# Altium schematic sheet Voltage Sense.SchDoc
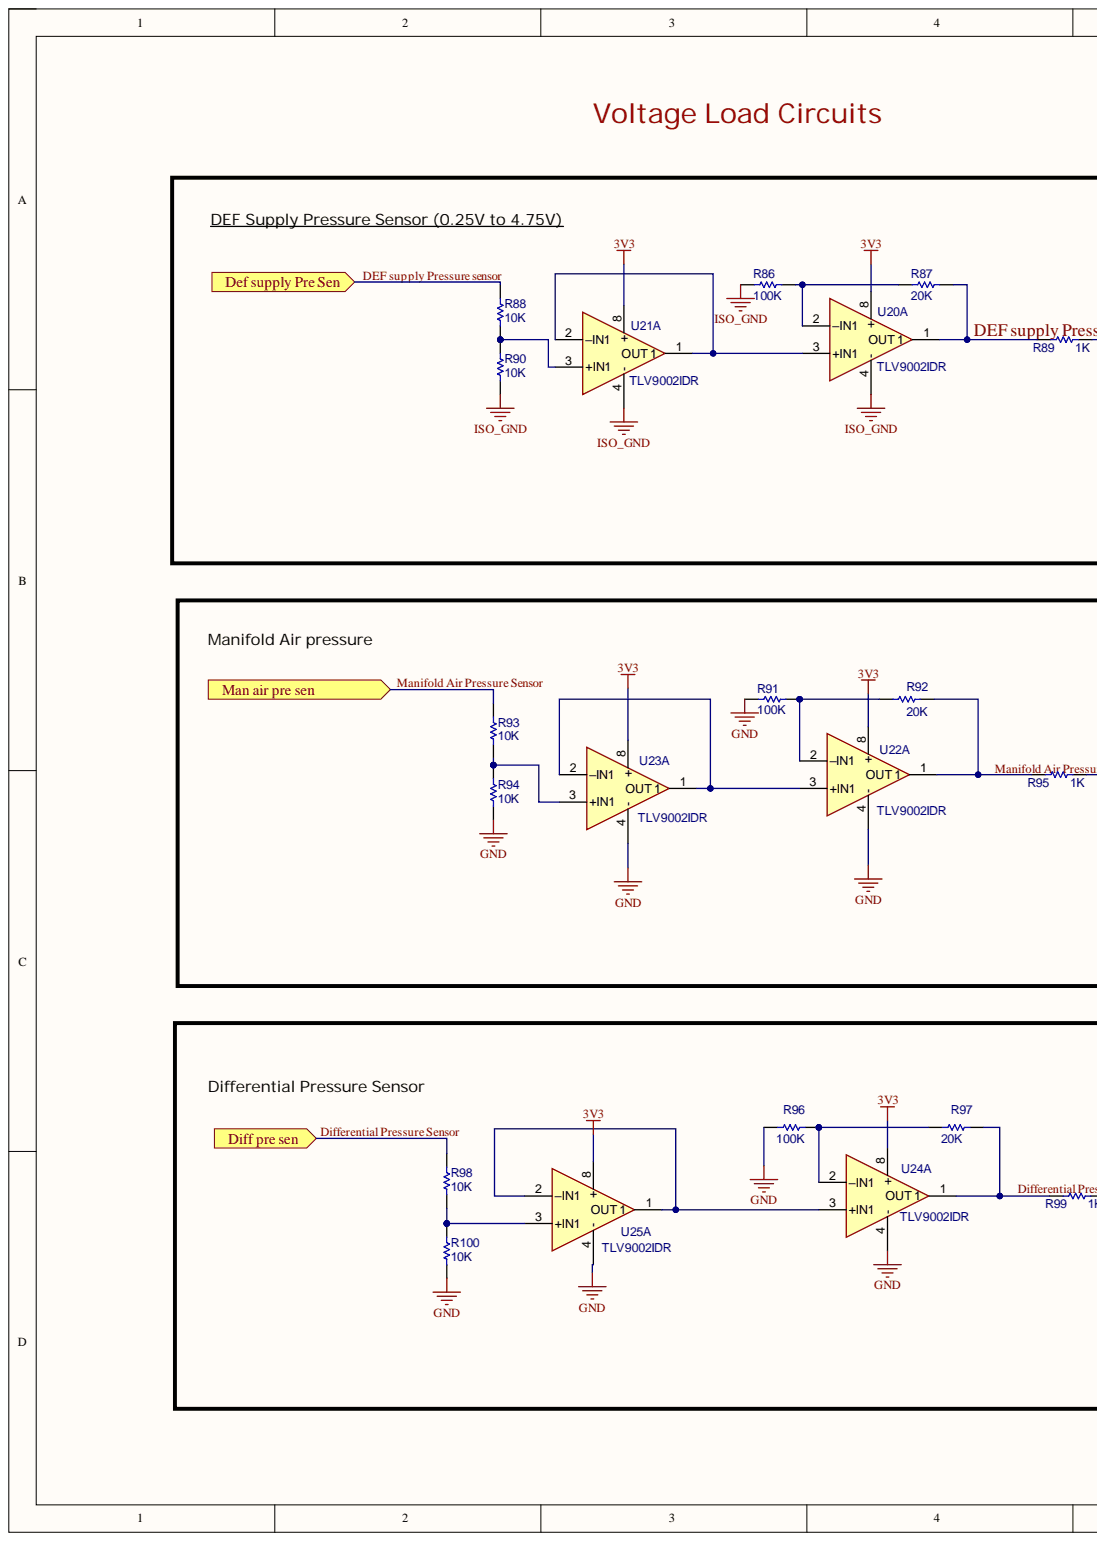
[diagram p0: Voltage Sense.SchDoc, left]
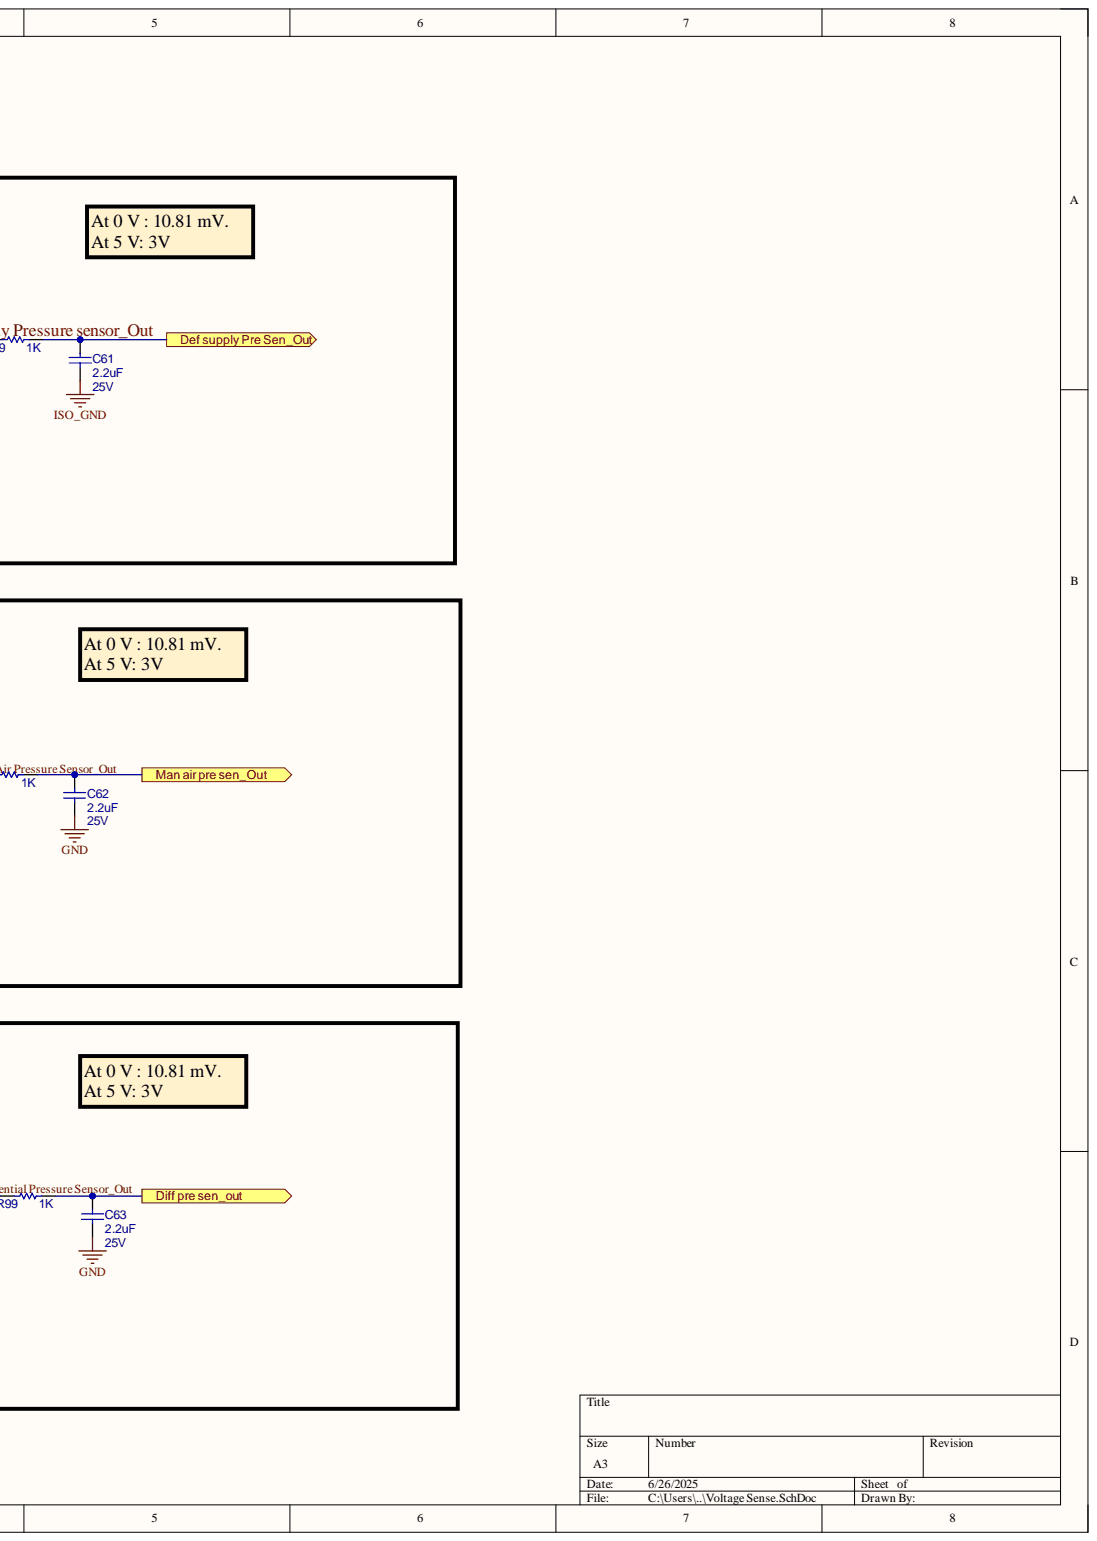
[diagram p1: Voltage Sense.SchDoc, right]

## Parts
C61  2.2uF  Voltage: 25V  [CAP-0603]
C62  2.2uF  Voltage: 25V  [CAP-0603]
C63  2.2uF  Voltage: 25V  [CAP-0603]
R86  100k  [RES-0603]
R87  20K  [RES-0603]
R88  10k  [RES-0603]
R89  1K  [RES-0603]
R90  10k  [RES-0603]
R91  100K  [RES-0603]
R92  20K  [RES-0603]
R93  10K  [RES-0603]
R94  10K  [RES-0603]
R95  1K  [RES-0603]
R96  100K  [RES-0603]
R97  20K  [RES-0603]
R98  10K  [RES-0603]
R99  1K  [RES-0603]
R100  10K  [RES-0603]
U20  TLV9002IDR  [SOIC127P599X175-8N]  (part A)
U21  TLV9002IDR  [SOIC127P599X175-8N]  (part A)
U22  TLV9002IDR  [SOIC127P599X175-8N]  (part A)
U23  TLV9002IDR  [SOIC127P599X175-8N]  (part A)
U24  TLV9002IDR  [SOIC127P599X175-8N]  (part A)
U25  TLV9002IDR  [SOIC127P599X175-8N]  (part A)

## Ports
Def supply Pre Sen, Def supply Pre Sen_Out, Diff pre sen, Diff pre sen_out, Man air pre sen, Man air pre sen_Out

## Nets
3V3: U20.8(+) U21.8(+) U22.8(+) U23.8(+) U24.8(+) U25.8(+)
GND: C62.2 C63.2 R91.2 R94.1 R96.2 R100.1 U22.4(-) U23.4(-) U24.4(-) U25.4(-)
ISO_GND: C61.2 R86.2 R90.1 U20.4(-) U21.4(-)
Def supply Pre Sen_Out: C61.1 R89.1 ; port Def supply Pre Sen_Out
DEF supply Pressure sensor: R88.2 ; port Def supply Pre Sen
DEF supply Pressure sensor_Out: R87.2 R89.2 U20.1(OUT_1)
Diff pre sen_out: C63.1 R99.1 ; port Diff pre sen_out
Differential Pressure Sensor: R98.2 ; port Diff pre sen
Differential Pressure Sensor_Out: R97.2 R99.2 U24.1(OUT_1)
Man air pre sen_Out: C62.1 R95.1 ; port Man air pre sen_Out
Manifold Air Pressure Sensor: R93.2 ; port Man air pre sen
NetR86_1: R86.1 R87.1 U20.2(–IN1)
NetR88_1: R88.1 R90.2 U21.3(+IN1)
NetR91_1: R91.1 R92.1 U22.2(–IN1)
NetR92_2: R92.2 R95.2 U22.1(OUT_1)
NetR93_1: R93.1 R94.2 U23.3(+IN1)
NetR96_1: R96.1 R97.1 U24.2(–IN1)
NetR98_1: R98.1 R100.2 U25.3(+IN1)
NetU20_3: U20.3(+IN1) U21.1(OUT_1) U21.2(–IN1)
NetU22_3: U22.3(+IN1) U23.1(OUT_1) U23.2(–IN1)
NetU24_3: U24.3(+IN1) U25.1(OUT_1) U25.2(–IN1)
Manifold Air Pressure Sensor_Out:

## Library data of the parts
C61-C63 = CAP: CAP CER 2.2UF 25V X5R 0603; Manufacturer: Taiyo Yuden; Part Number: TMK107ABJ225KA-T; Tolerance: ±1%; Component Kind: Standard; Library Name: Daughter_board_SE3_V1.SCHLIB; Package: 0603; Technology: SMT; Value: 2.2uF
R86 = RES-2: RES SMD 100K OHM 1% 1/10W 0603,MCT06030C1003FP500; Manufacturer: YAGEO; Part Number: AC0603FR-07100KL; Power Rating: 100mW; Component Kind: Standard; Library Name: Daughter_board_SE3_V1.SCHLIB; Package: 0603; Tolerance: ±1%; Value: 100K
R87 R92 R97 = RES-2_28: RES 20K OHM 1% 1/10W 0603; Manufacturer: YAGEO; Part Number: RC0603FR-0720KL; Case/Package: 0603; Component Kind: Standard; Tolerance: ±1%; Value: 20K; Library Name: GENSET CONTROLLER BETA V01.SCHLIB
R88 R90 = RES-2_14: RES SMD 10K OHM 1% 1/10W 0603,CRCW060310K0FKEI; Manufacturer: YAGEO; Part Number: AC0603FR-0710KL; Power Rating: 100mW; Component Kind: Standard; Package: 0603; Tolerance: ±1%; Value: 10K; Library Name: GENSET CONTROLLER BETA V01.SCHLIB
R89 R95 R99 = RES-2: RES SMD 1K OHM 1% 1/10W 0603; Manufacturer: YAGEO; Part Number: AC0603FR-071KL; Power Rating: 100mW; Component Kind: Standard; Library Name: Daughter_board_SE3_V1.SCHLIB; Package: 0603; Tolerance: ±1%; Value: 1K
R91 R96 = RES-2: RES SMD 100K OHM 1% 1/10W 0603; Manufacturer: YAGEO; Part Number: AC0603FR-07100KL; Power Rating: 100mW; Component Kind: Standard; Library Name: Daughter_board_SE3_V1.SCHLIB; Package: 0603; Tolerance: ±1%; Value: 100K
R93 R94 R98 R100 = RES-2_14: RES SMD 10K OHM 1% 1/10W 0603; Manufacturer: YAGEO; Part Number: AC0603FR-0710KL; Power Rating: 100mW; Component Kind: Standard; Package: 0603; Tolerance: ±1%; Value: 10K; Library Name: GENSET CONTROLLER BETA V01.SCHLIB
U20-U25 = TLV9002IDR: IC OPAMP GP 2 CIRCUIT 8SOIC; Manufacturer: Texas Instruments; Component Kind: Standard; Part Number: TLV9002IDR; Library Name: GENSET CONTROLLER BETA V1.SCHLIB
